# Altium schematic sheet Memory.SchDoc
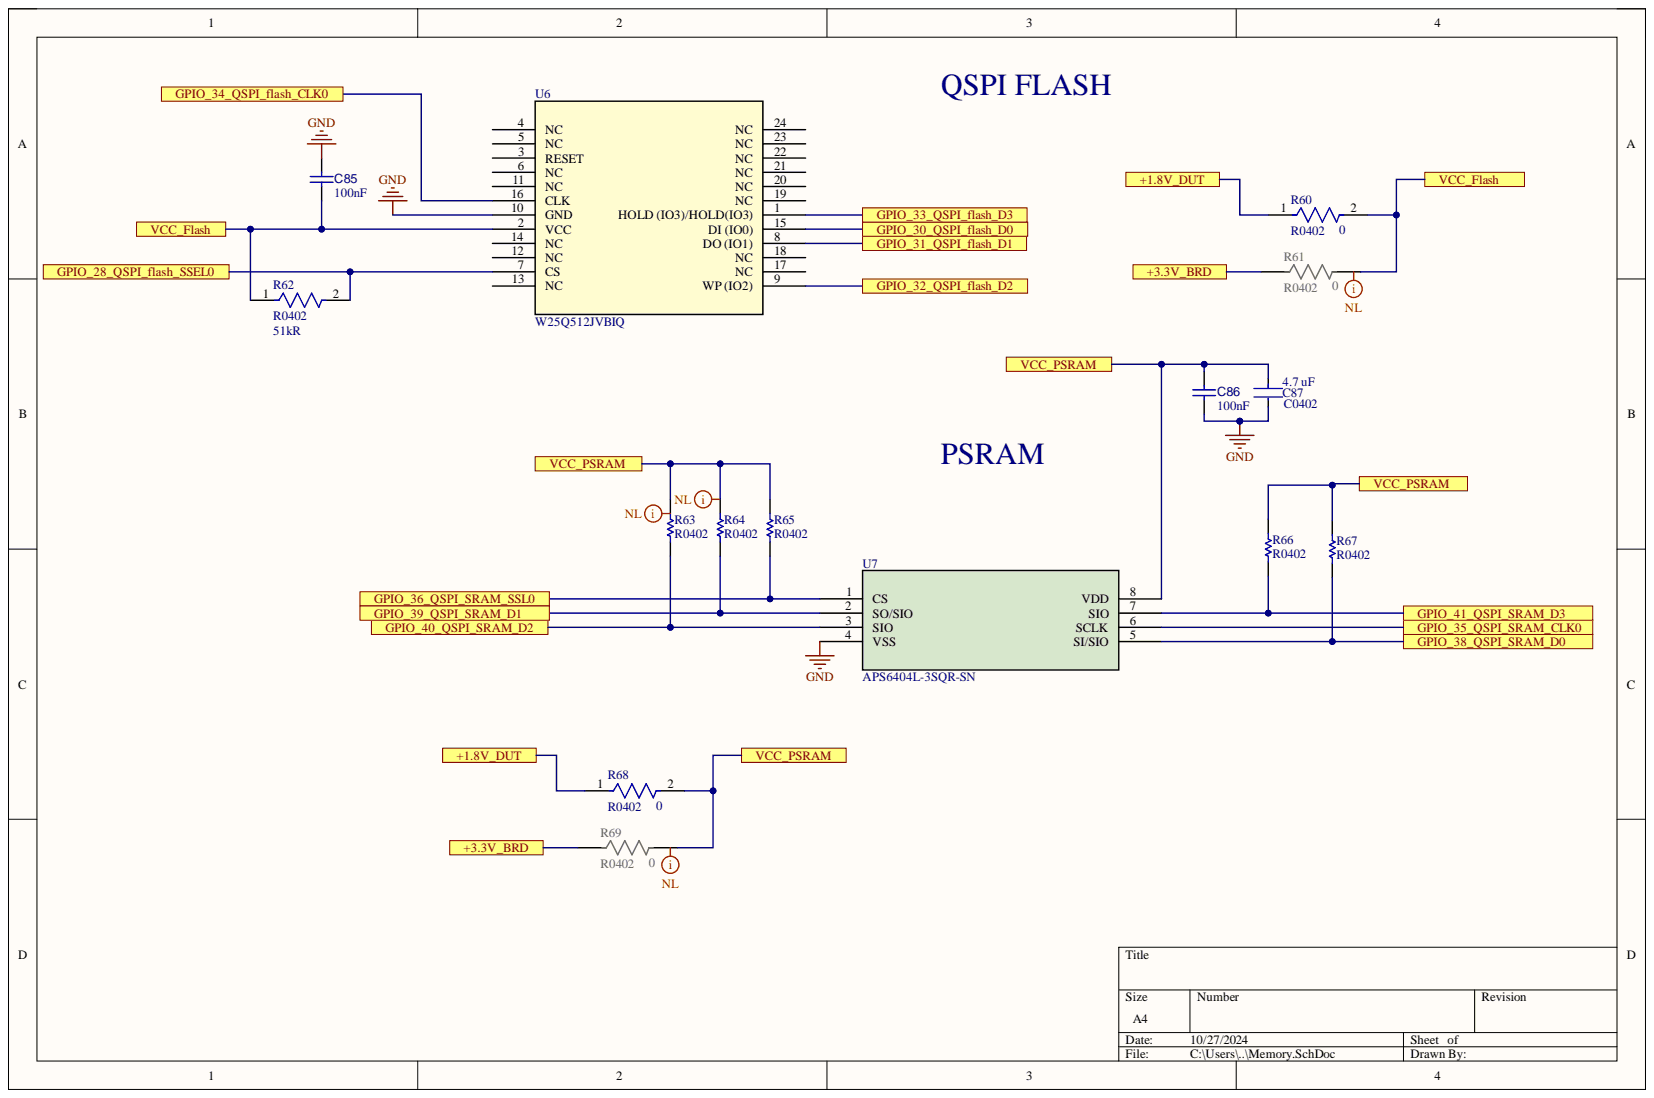
[diagram p0: Memory.SchDoc]

## Parts
C85  value 100nF  [CAPC0402(1005)60_L]  (hidden comment: Capacitor 100nF +/-20% 10V 0402)
C86  value 100nF  [CAPC0402(1005)60_L]  (hidden comment: Capacitor 100nF +/-20% 10V 0402)
C87  C0402  value 4.7 uF  Capacitance: 4.7 uF  [FP-CC0402-MFG]
R60  R0402  [FP-CR0402-IPC_A]
R61  R0402  [FP-CR0402-IPC_A]
R62  R0402  Resistance: 51kR  [FP-CR0402-IPC_A]
R63  R0402  [RESC1005X40X25NL10T10]
R64  R0402  [RESC1005X40X25NL10T10]
R65  R0402  [RESC1005X40X25NL10T10]
R66  R0402  [RESC1005X40X25NL10T10]
R67  R0402  [RESC1005X40X25NL10T10]
R68  R0402  [FP-CR0402-IPC_A]
R69  R0402  [FP-CR0402-IPC_A]
U6  W25Q512JVBIQ  [BGA_2JVBIQ_WIN]
U7  APS6404L-3SQR-SN  [APS6404L-3SQR-SN_APY]

## Ports
+1.8V_DUT, +3.3V_BRD, GPIO_28_QSPI_flash_SSEL0, GPIO_30_QSPI_flash_D0, GPIO_31_QSPI_flash_D1, GPIO_32_QSPI_flash_D2, GPIO_33_QSPI_flash_D3, GPIO_34_QSPI_flash_CLK0, GPIO_35_QSPI_SRAM_CLK0, GPIO_36_QSPI_SRAM_SSL0, GPIO_38_QSPI_SRAM_D0, GPIO_39_QSPI_SRAM_D1, GPIO_40_QSPI_SRAM_D2, GPIO_41_QSPI_SRAM_D3, VCC_Flash, VCC_PSRAM

## Nets
GND: C85.1 C86.1 C87.2 U6.10(GND) U7.4(VSS)
+1.8V_DUT: R60.1 R68.1 ; port +1.8V_DUT
+3.3V_BRD: R61.1 R69.1 ; port +3.3V_BRD
GPIO_28_QSPI_flash_SSEL0: R62.2 U6.7(CS) ; port GPIO_28_QSPI_flash_SSEL0
GPIO_30_QSPI_flash_D0: U6.15(DI_(IO0)) ; port GPIO_30_QSPI_flash_D0
GPIO_31_QSPI_flash_D1: U6.8(DO_(IO1)) ; port GPIO_31_QSPI_flash_D1
GPIO_32_QSPI_flash_D2: U6.9(WP_(IO2)) ; port GPIO_32_QSPI_flash_D2
GPIO_33_QSPI_flash_D3: U6.1 ; port GPIO_33_QSPI_flash_D3
GPIO_34_QSPI_flash_CLK0: U6.16(CLK) ; port GPIO_34_QSPI_flash_CLK0
GPIO_35_QSPI_SRAM_CLK0: U7.6(SCLK) ; port GPIO_35_QSPI_SRAM_CLK0
GPIO_36_QSPI_SRAM_SSL0: R65.1 U7.1(CS) ; port GPIO_36_QSPI_SRAM_SSL0
GPIO_38_QSPI_SRAM_D0: R67.1 U7.5(SI/SIO) ; port GPIO_38_QSPI_SRAM_D0
GPIO_39_QSPI_SRAM_D1: R64.1 U7.2(SO/SIO) ; port GPIO_39_QSPI_SRAM_D1
GPIO_40_QSPI_SRAM_D2: R63.1 U7.3(SIO) ; port GPIO_40_QSPI_SRAM_D2
GPIO_41_QSPI_SRAM_D3: R66.1 U7.7(SIO) ; port GPIO_41_QSPI_SRAM_D3
VCC_Flash: C85.2 R60.2 R61.2 R62.1 U6.2(VCC) ; port VCC_Flash
VCC_PSRAM: C86.2 C87.1 R63.2 R64.2 R65.2 R66.2 R67.2 R68.2 R69.2 U7.8(VDD) ; port VCC_PSRAM
NetU6_3: U6.3(RESET)
NetU6_4: U6.4(NC)
NetU6_5: U6.5(NC)
NetU6_6: U6.6(NC)
NetU6_11: U6.11(NC)
NetU6_12: U6.12(NC)
NetU6_13: U6.13(NC)
NetU6_14: U6.14(NC)
NetU6_17: U6.17(NC)
NetU6_18: U6.18(NC)
NetU6_19: U6.19(NC)
NetU6_20: U6.20(NC)
NetU6_21: U6.21(NC)
NetU6_22: U6.22(NC)
NetU6_23: U6.23(NC)
NetU6_24: U6.24(NC)

## Library data of the parts
C85 C86 = CMP-001-00061-3: Chip Capacitor, 100nF +/-20%, 10V, 0402, Thickness 0.6 mm; Tolerance: 20%; Case/Package: 0402; Component Kind: Standard; Library Name: Altium Personal Space; Max Operating Temperature: 85°C; Min Operating Temperature: -55°C; Mounting Technology: SMT; Pins: 2; Voltage Rating: 10V
C87 = CMP-03422-000823-1: CAP CER 4.7UF 10V X5R 0402; Manufacturer: YAGEO; Manufacturer Part Number: CC0402KRX5R6BB475; Automotive Grade: NA; Material: Ceramic; Temperature Coefficient: 30ppm/°C; Tolerance: 0.1; Automotive: No; Category: Cap; Component Kind: Standard; ComponentLink2Description: Datasheet; ComponentLink2URL: http://www.yageo.com/documents/recent/UPY-GP_NP0_16V-to-50V_16.pdf; Device Class L1: Passive Components; Device Class L2: Capacitors; Device Class L3: Ceramic Capacitors; Digikey Description: CAP CER 4.7UF 10V X5R 0402; Footprint Url: http://www.yageo.com/exep/pages/download/literatures/UPY-C_GEN_24.pdf; Height: 0.55mm; Ipc Land Pattern Name: CAPC10050X50; Lead Free: Yes; Library Name: Altium Content Vault; Mouser Description: CC Series 0402 4.7 uF 10 V ±10% Tolerance X5R Multilayer Ceramic Capacitor; Octopart: https://octopart.com/cc0402krx5r6bb475-yageo-77039651; Package: CC0402; RoHS: TRUE; Temperature: -55°C to +125°C; Temperature Characteristic: X5R; Temperature Range High: +125°C; Temperature Range Low: -55°C; Voltage Rating: 10 V
R60 R61 R68 R69 = CMP-2002-05253-2: Thick Film Chip Resistors 0402 0Ω; Max Power Dissipation: 63mW; Power Rating: 62.5mW; Resistance: 0; Temperature Coefficient: 100ppm/°C; Tolerance: 1%; Voltage Rating: 50V; Case Code (Imperial): 0402; Case Code (Metric): 1005; Case/Package: 0402; Component Kind: Standard; Composition: Thick Film; Contact Plating: Tin; Depth: 0.5mm; Height: 0.016inch; Length: 1mm; Library Name: Altium Content Vault; Max Operating Temperature: 125°C; Military Standard: Not; Min Operating Temperature: -55°C; Mount: Surface Mount; Number of Terminations: 2; Package Quantity: 10000; Packaging: Tape and Reel; Radiation Hardening: No; Termination: SMD/SMT; Thickness: 356um; Width: 0.02inch
R62 = CMP-2002-05253-2: Thick Film Chip Resistors 0402 51kΩ 0.0625W 1% 100ppm/°C; Max Power Dissipation: 63mW; Power Rating: 62.5mW; Voltage Rating: 50V; Case Code (Imperial): 0402; Case Code (Metric): 1005; Case/Package: 0402; Component Kind: Standard; Composition: Thick Film; Contact Plating: Tin; Depth: 0.5mm; Height: 0.016inch; Length: 1mm; Library Name: Altium Content Vault; Max Operating Temperature: 125°C; Military Standard: Not; Min Operating Temperature: -55°C; Mount: Surface Mount; Number of Terminations: 2; Package Quantity: 10000; Packaging: Tape and Reel; Radiation Hardening: No; Temperature Coefficient: 100ppm/°C; Termination: SMD/SMT; Thickness: 356um; Tolerance: 1%; Width: 0.02inch
R63-R67 = CMP-2002-04694-1: Manufacturer: Bourns; Part Number: CR0402-FX-1002GLF; Component Kind: Standard; Library Name: Altium Content Vault
U6 = W25Q512JVBIQ: No Description Available; Mfr_Name: Winbond; Component Kind: Standard; Library Name: W25Q512JVBIQ.IntLib; RefDes: RefDes; Type: Type
U7 = APS6404L-3SQR-SN: No Description Available; Mfr_Name: AP Memory; Component Kind: Standard; Library Name: APS6404L-3SQR-SN.IntLib; RefDes: RefDes; Type: Type
